# Altium schematic sheet DevBoard_nRF54L15.SchDoc
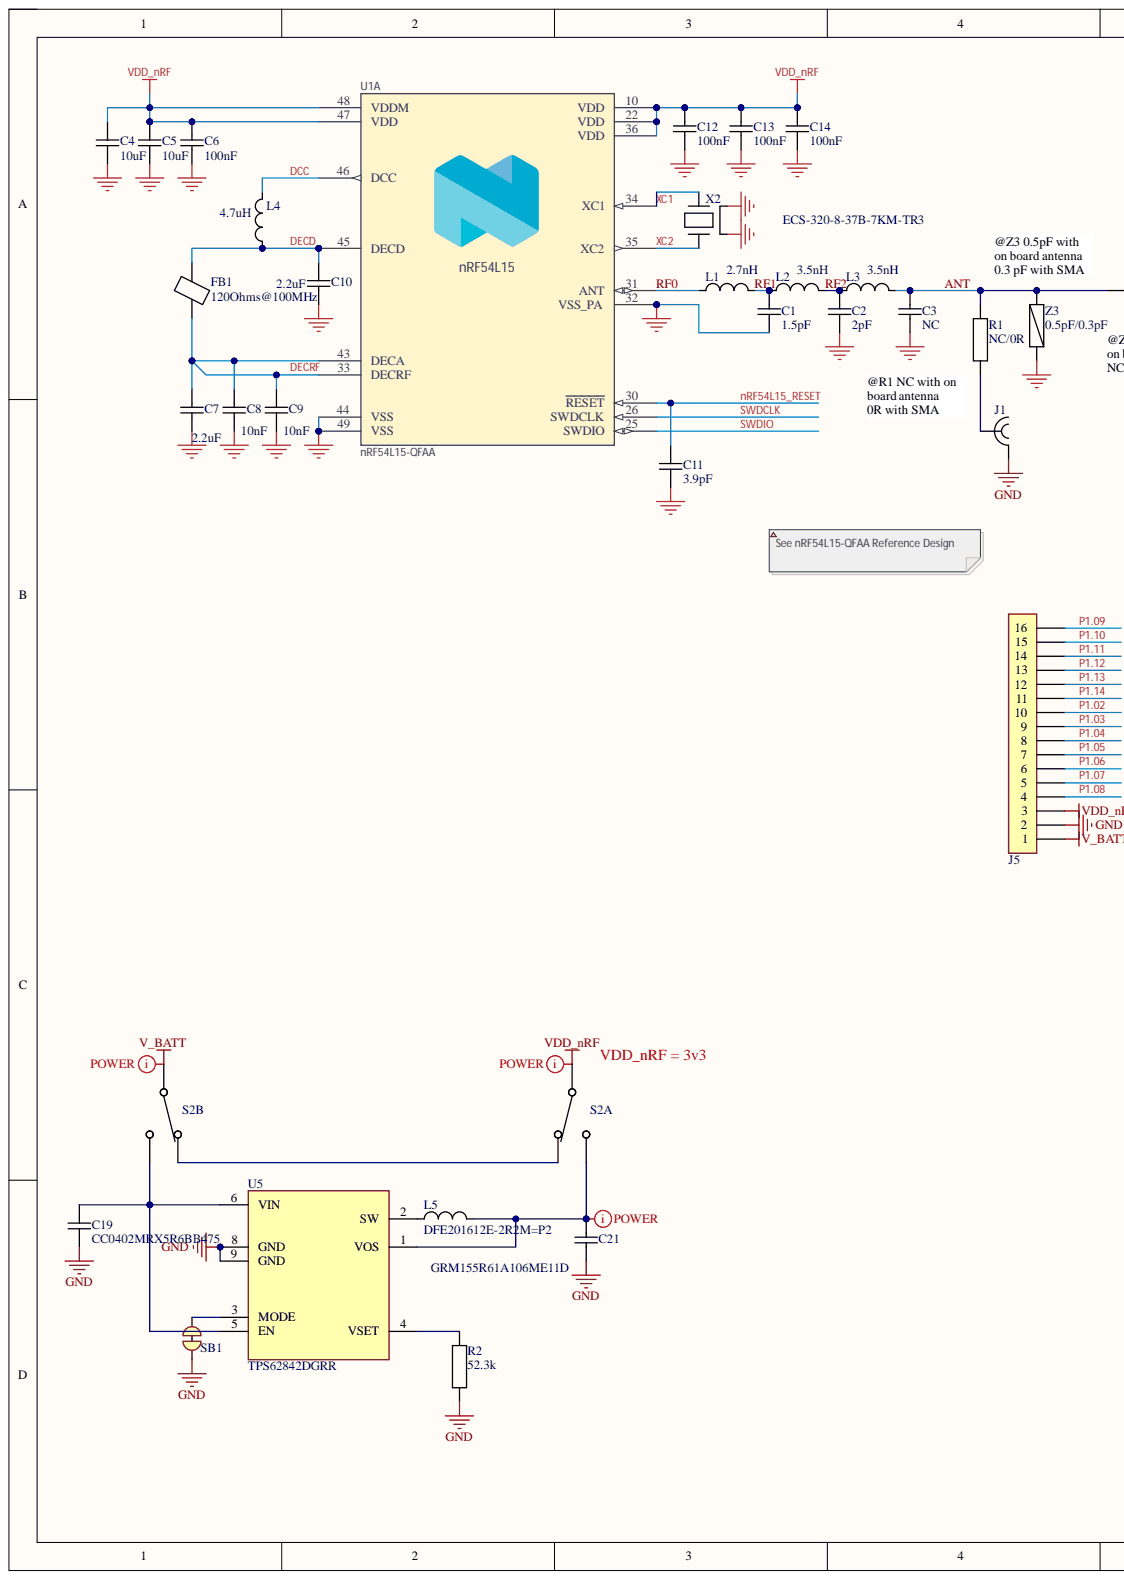
[diagram p0: DevBoard_nRF54L15.SchDoc, left]
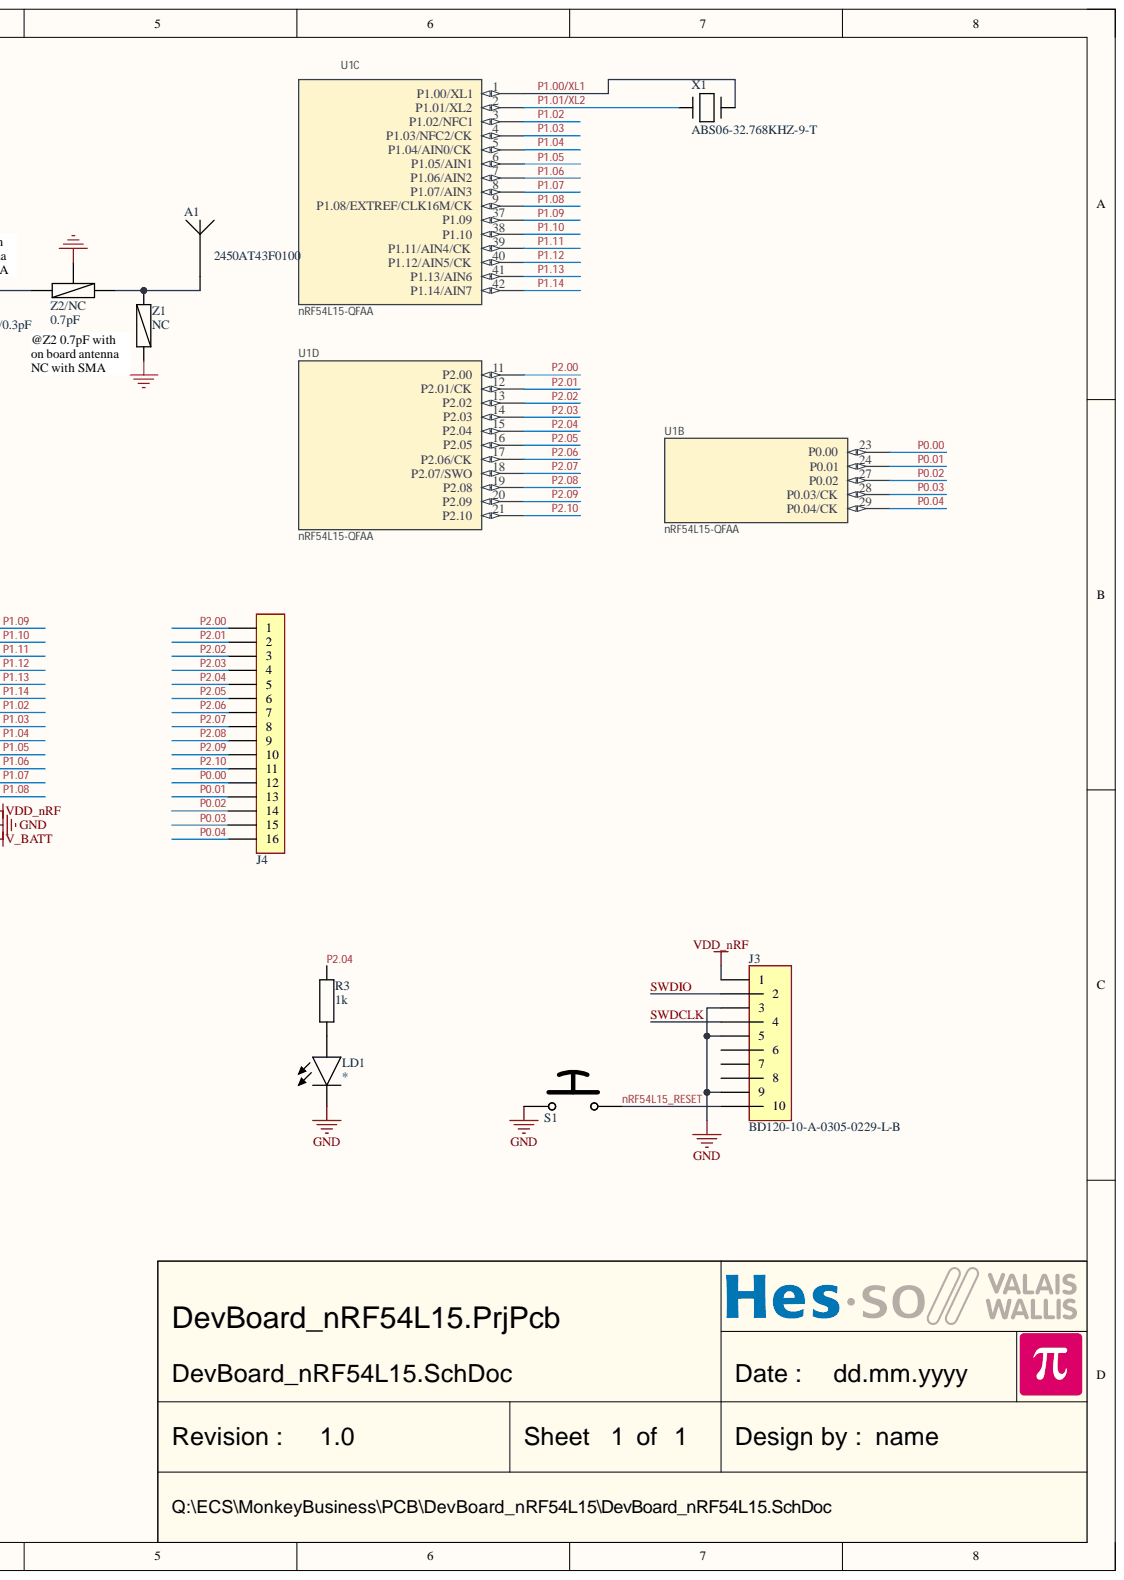
[diagram p1: DevBoard_nRF54L15.SchDoc, right]

## Parts
A1  2450AT43F0100  [ANTENNA Ceramic Johanson 2450AT43F0100]
C1  1.5pF  [CAPACITOR 1005 REFLOW]
C2  2pF  [CAPACITOR 1005 REFLOW]
C3  NC  [CAPACITOR 1005 REFLOW]
C4  10uF  [CAPACITOR 1005 REFLOW]
C5  10uF  [CAPACITOR 1005 REFLOW]
C6  100nF  [CAPACITOR 1005 REFLOW]
C7  2.2uF  [CAPACITOR 1005 REFLOW]
C8  10nF  [CAPACITOR 1005 REFLOW]
C9  10nF  [CAPACITOR 1005 REFLOW]
C10  2.2uF  [CAPACITOR 1005 REFLOW]
C11  3.9pF  [CAPACITOR 1005 REFLOW]
C12  100nF  [CAPACITOR 1005 REFLOW]
C13  100nF  [CAPACITOR 1005 REFLOW]
C14  100nF  [CAPACITOR 1005 REFLOW]
C19  CC0402MRX5R6BB475  [CAPACITOR 1005 REFLOW]
C21  GRM155R61A106ME11D  [CAPACITOR 1005 REFLOW]
FB1  120Ohms@100MHz  [FERRITE BEAD 1608 REFLOW]
J1  732511150  [SMA EDGE Molex 732511150]  (value not drawn)
J3  BD120-10-A-0305-0229-L-B  [FlatCable2x5 1.27MM Straight TH Mini]
J4  TSW-116-07-F-S  [PinHeader1x16 P2.54]  (value not drawn)
J5  TSW-116-07-F-S  [PinHeader1x16 P2.54]  (value not drawn)
L1  2.7nH  [INDUCTOR 1005 REFLOW]
L2  3.5nH  [INDUCTOR 1005 REFLOW]
L3  3.5nH  [INDUCTOR 1005 REFLOW]
L4  4.7uH  [INDUCTOR 1608 REFLOW]
L5  DFE201612E-2R2M=P2  [INDUCTOR 2012 REFLOW]
LD1  (no value)  [LED 2012 REFLOW]
R1  NC/0R  [RESISTOR 1005 REFLOW]
R2  52.3k  [RESISTOR 1005 REFLOW]
R3  1k  [RESISTOR 1005 REFLOW]
S1  434781025816  [Push Button C6.2x4.1 WE 434781025816]  (value not drawn)
S2  EG2208A  [EG2208A]  (value not drawn)  (parts A,B)
SB1  (no value)  [Solder Bridge Holes Connected]
U1  nRF54L15-QFAA  [QFN48 P0.4 T4.6X4.6 C6.0X6.0 H0.9]  (parts A,B,C,D)
U5  TPS62842DGRR  [SSOP8 P0.65 T1.3x1.6 C4.9x3.0 H1.1]
X1  ABS06-32.768KHZ-9-T  [Crystal C2.0x1.2 Abracon ABS06]
X2  ECS-320-8-37B-7KM-TR3  [Crystal C2.0x1.6 Kyocera CX2016DB]
Z1  NC  [Matching pad 1005]
Z2/NC  0.7pF  [Matching pad 1005 with Ground]
Z3  0.5pF/0.3pF  [Matching pad 1005]

## Nets
GND: C1.1 C2.1 C3.1 C4.1 C5.1 C6.1 C7.1 C8.1 C9.1 C10.1 C11.1 C12.1 C13.1 C14.1 C19.1 C21.1 J1.2 J3.3 J3.5 J3.9 J5.2 LD1.1 R2.1 S1.1 SB1.2 U1.32(VSS_PA) U1.44(VSS) U1.49(VSS) U5.8(GND) U5.9(GND) X2.2 X2.4 Z1.1 Z2/NC.3 Z3.1
V_BATT: J5.1 S2.5
VDD_nRF: C4.2 C5.2 C6.2 C12.2 C13.2 C14.2 J3.1 J5.3 S2.2 U1.10(VDD) U1.22(VDD) U1.36(VDD) U1.47(VDD) U1.48(VDDM)
ANT: C3.2 L3.2 R1.2 Z2/NC.2 Z3.2
DCC: L4.2 U1.46(DCC)
DECD: C10.2 FB1.2 L4.1 U1.45(DECD)
DECRF: C7.2 C8.2 C9.2 FB1.1 U1.33(DECRF) U1.43(DECA)
nRF54L15_RESET: C11.2 J3.10 S1.2 U1.30(RESET)
P0.00: J4.12 U1.23(P0.00)
P0.01: J4.13 U1.24(P0.01)
P0.02: J4.14 U1.27(P0.02)
P0.03: J4.15 U1.28(P0.03/CK)
P0.04: J4.16 U1.29(P0.04/CK)
P1.00/XL1: U1.1(P1.00/XL1) X1.1
P1.01/XL2: U1.2(P1.01/XL2) X1.2
P1.02: J5.10 U1.3(P1.02/NFC1)
P1.03: J5.9 U1.4(P1.03/NFC2/CK)
P1.04: J5.8 U1.5(P1.04/AIN0/CK)
P1.05: J5.7 U1.6(P1.05/AIN1)
P1.06: J5.6 U1.7(P1.06/AIN2)
P1.07: J5.5 U1.8(P1.07/AIN3)
P1.08: J5.4 U1.9(P1.08/EXTREF/CLK16M/CK)
P1.09: J5.16 U1.37(P1.09)
P1.10: J5.15 U1.38(P1.10)
P1.11: J5.14 U1.39(P1.11/AIN4/CK)
P1.12: J5.13 U1.40(P1.12/AIN5/CK)
P1.13: J5.12 U1.41(P1.13/AIN6)
P1.14: J5.11 U1.42(P1.14/AIN7)
P2.00: J4.1 U1.11(P2.00)
P2.01: J4.2 U1.12(P2.01/CK)
P2.02: J4.3 U1.13(P2.02)
P2.03: J4.4 U1.14(P2.03)
P2.04: J4.5 R3.2 U1.15(P2.04)
P2.05: J4.6 U1.16(P2.05)
P2.06: J4.7 U1.17(P2.06/CK)
P2.07: J4.8 U1.18(P2.07/SWO)
P2.08: J4.9 U1.19(P2.08)
P2.09: J4.10 U1.20(P2.09)
P2.10: J4.11 U1.21(P2.10)
RF0: L1.1 U1.31(ANT)
RF1: C1.2 L1.2 L2.1
RF2: C2.2 L2.2 L3.1
SWDCLK: J3.4 U1.26(SWDCLK)
SWDIO: J3.2 U1.25(SWDIO)
XC1: U1.34(XC1) X2.3
XC2: U1.35(XC2) X2.1
NetA1_1: A1.1 Z1.2 Z2/NC.1
NetC19_2: C19.2 S2.4 U5.5(EN) U5.6(VIN)
NetC21_2: C21.2 L5.2 S2.1 U5.1(VOS)
NetJ1_1: J1.1 R1.1
NetL5_1: L5.1 U5.2(SW)
NetLD1_2: LD1.2 R3.1
NetR2_2: R2.2 U5.4(VSET)
NetS2_3: S2.3 S2.6
NetSB1_1: SB1.1 U5.3(MODE)

Not connected: J3 6 7 8

## Library data of the parts
A1 = ANTENNA Ceramic Johanson 2450AT43F0100: ANTENNA Ceramic Johanson 2450AT42A100; Component Kind: Standard; Library Name: WirelessDevice.SchLib; Value: 2450AT43F0100
C1-C3 C11 = Capacitor: Temp Coef: NP0; Tolerance: 0.05pF; Voltage: 25V; Component Kind: Standard; Description: Capacitor; Library Name: Capacitor.SchLib
  C1: Value: 1.5pF
  C2: Value: 2pF
  C3: Value: NC
  C11: Value: 3.9pF
C4-C6 C12-C14 = Capacitor: Temp Coef: X6S; Tolerance: 20%; Voltage: 6.3V; Component Kind: Standard; Description: Capacitor; Library Name: Capacitor.SchLib
  C4 C5: Value: 10uF
  C6 C12-C14: Value: 100nF
C7 C10 = Capacitor: Temp Coef: X6T; Tolerance: 20%; Voltage: 2.5V; Component Kind: Standard; Description: Capacitor; Library Name: Capacitor.SchLib; Value: 2.2uF
C8 C9 = Capacitor: Temp Coef: X7R; Tolerance: 10%; Component Kind: Standard; Description: Capacitor; Library Name: Capacitor.SchLib; Value: 10nF
C19 C21 = Capacitor: Component Kind: Standard; Description: Capacitor; Library Name: Capacitor.SchLib
  C19: Value: CC0402MRX5R6BB475
  C21: Value: GRM155R61A106ME11D
FB1 = Ferrite Bead: Manufacturer Part Number 1: BLM03AG121SN1; Current: 200mA; DC res: 500m; Component Kind: Standard; Description: Ferrite Bead; Library Name: Inductor.SchLib; Value: 120Ohms@100MHz
J1 = SMA EDGE Molex 732511150: Component Kind: Standard; Description: SMA EDGE Molex 732511150; Library Name: Connector.SchLib; Value: 732511150
J3 = FlatCable2x5: Component Kind: Standard; Description: FlatCable2x5; Library Name: Connector.SchLib; Value: BD120-10-A-0305-0229-L-B
J4 J5 = PinHeader1x16: Plug connector; Component Kind: Standard; Library Name: PinHeader.SchLib; Value: TSW-116-07-F-S
L1 = Inductor: Manufacturer Part Number 1: LQW15AN2N7B8ZD; Current: 600mA; DC res: 120m; Tolerance: 0.1nH; Component Kind: Standard; Description: Inductor; Library Name: Inductor.SchLib; Value: 2.7nH
L2 L3 = Inductor: Manufacturer Part Number 1: LQW15AN3N5B8ZD; Current: 600mA; DC res: 120m; Tolerance: 0.1nH; Component Kind: Standard; Description: Inductor; Library Name: Inductor.SchLib; Value: 3.5nH
L4 = Inductor: Manufacturer Part Number 1: MLZ1608M4R7WT000; Current: 350mA; DC res: 500m; Tolerance: 20%; Component Kind: Standard; Description: Inductor; Library Name: Inductor.SchLib; Value: 4.7uH
L5 = Inductor: Component Kind: Standard; Description: Inductor; Library Name: Inductor.SchLib; Value: DFE201612E-2R2M=P2
LD1 = LED: LED generic; Component Kind: Standard; Library Name: Diode.SchLib
R1 R3 = Resistor: Component Kind: Standard; Description: Resistor; Library Name: Resistor.SchLib
  R1: Value: NC/0R
  R3: Value: 1k
R2 = Resistor: Manufacturer Part Number 1: CRCW040252K3FKED; Tolerance: 0.1%; Component Kind: Standard; Description: Resistor; Library Name: Resistor.SchLib; Value: 52.3k
S1 = Push Button 2Pins: Push Button, 2 pins; Component Kind: Standard; Library Name: Button.SchLib; Value: 434781025816
S2 = Slide Switch 2Poles ON-ON: Component Kind: Standard; Description: Slide Switch 2Poles ON-ON; Value: EG2208A; Library Name: ECSlib.SchLib
SB1 = Solderbridge Connected: Component Kind: Net Tie; Description: Solderbridge Connected; Library Name: ECSlib.SchLib
U1 = nRF54L15-QFAABB0: Multi-protocol Bluetooth Low Energy, IEEE 802.15.4, ANT and 2.4GHz proprietary system-on-chip; Manufacturer: Nordic Semiconductor; Artikkelnr Noca: N.A.; Component Status: Active; Database Table Name: Parts$; Pad Count: 49; Component Class: Nordic_Devices; Component Kind: Standard; ComponentLink1Description: Datasheet; ComponentLink1URL: N.A.; ComponentLink2Description: Supplier link; ComponentLink2URL: N.A.; Footprint Doc: QFN-48; HelpURL: N.A.; LatestRevisionDate: 2024-06-19; Library Name: AltiumLib.DbLib; Manufacturer Part Number: nRF54L15-QFAABB0; Note: N.A.; Part Number: nRF54L15-QFAABB0; Part number IPS: N.A.; Part number Norautron: N.A.; PartId: 102000348; Published: 2024-06-19; Supplier 1: Nordic Semiconductor; Supplier 2: N.A.; Supplier Part Number 1: nRF54L15-QFAABB0; Supplier Part Number 2: N.A.; Value: nRF54L15-QFAA; Author: stl1
U5 = TPS62840DGR: 1.8-V to 6.5-V, Step-Down Converter; Component Kind: Standard; Library Name: VoltageConverter.SchLib; Value: TPS62842DGRR
X1 = Crystal C2.0x1.2 Abracon ABS06: Component Kind: Standard; Description: Crystal C2.0x1.2 Abracon ABS06; Library Name: Oscillator.SchLib; Value: ABS06-32.768KHZ-9-T
X2 = Crystal C2.0x1.6 Kyocera CX2016DB: XTAL SMD 2016, 32MHz, Cl=8pF, Tol: ±7ppm, Stab: ±10ppm; Component Kind: Standard; Library Name: Oscillator.SchLib; Value: ECS-320-8-37B-7KM-TR3
Z1 Z3 = Matching pad: Component Kind: Standard; Description: Matching pad; Library Name: WirelessDevice.SchLib
  Z1: Value: NC
  Z3: Value: 0.5pF/0.3pF
Z2/NC = Matching pad with ground: Antenna matching pad with ground; Component Kind: Standard; Library Name: WirelessDevice.SchLib; Value: 0.7pF
